# Altium schematic sheet FPGA.SchDoc(FPGA)
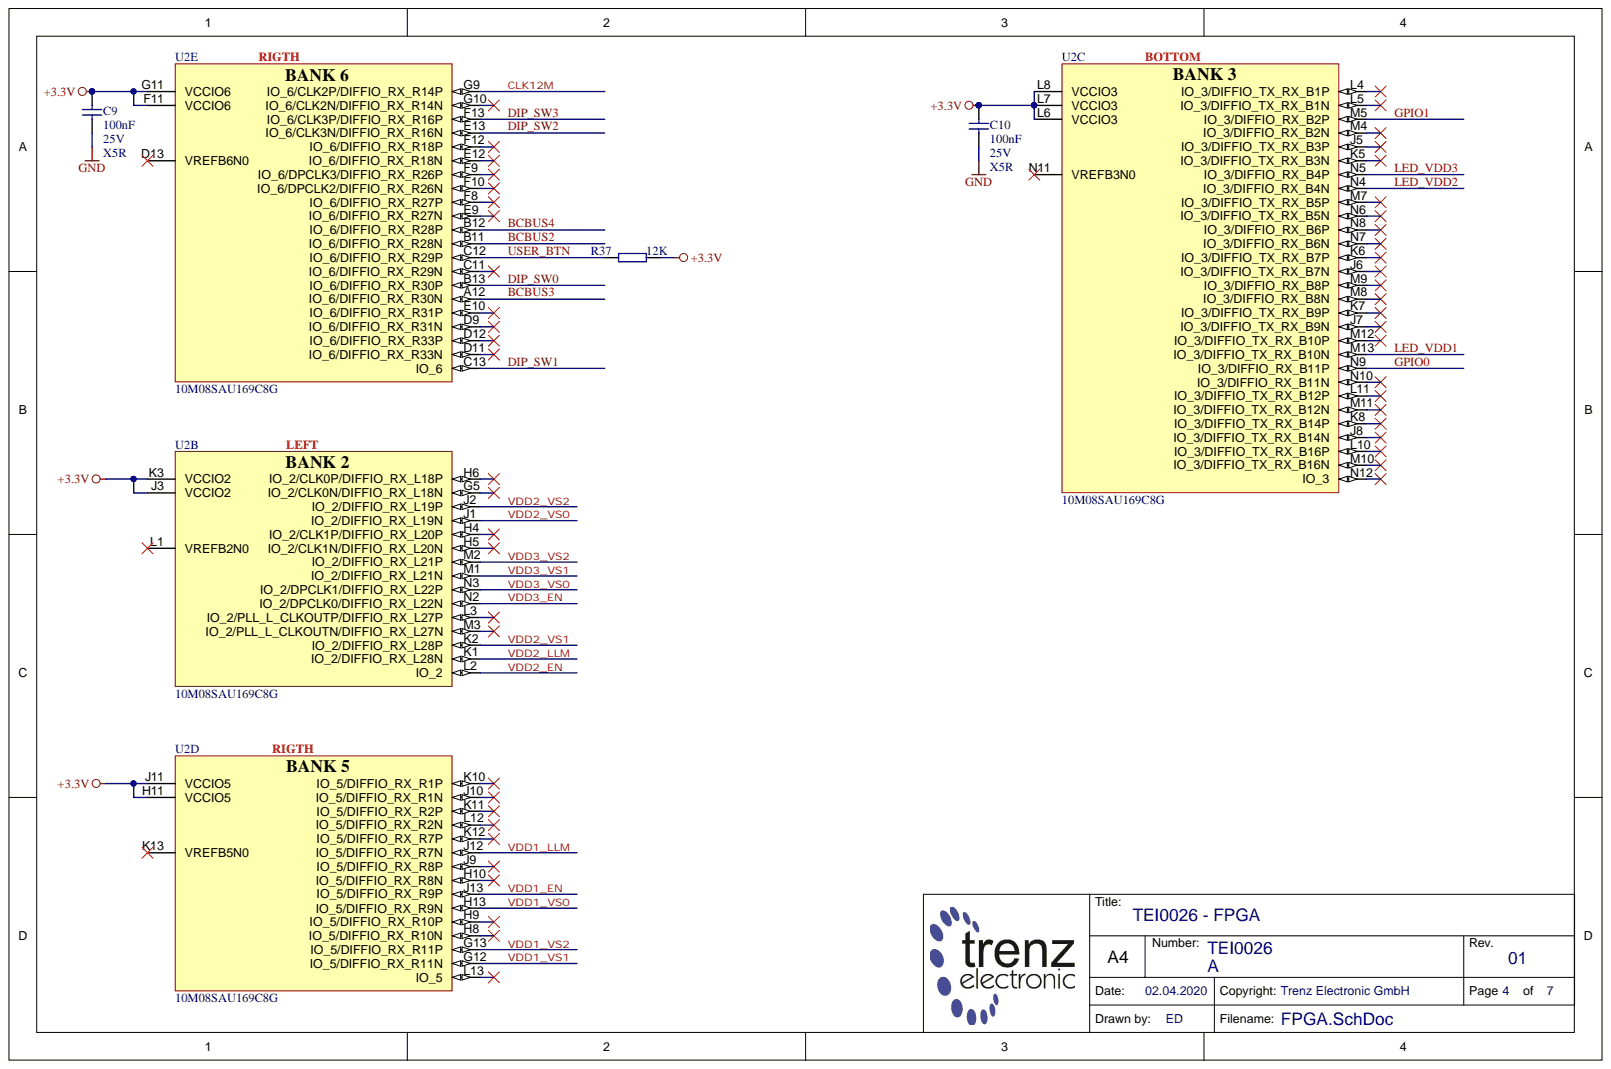
[diagram p0: FPGA.SchDoc]

## Parts
C9  100nF  Voltage: 25V  Technology: X5R  [CAPC1005X55N]
C10  100nF  Voltage: 25V  Technology: X5R  [CAPC1005X55N]
R37  12K  [RESC1005X40N]
U2  10M08SAU169C8G  [BGA169C80P13X13_11X11X15]  (parts B,C,D,E)

## Nets
+3.3V: C9.1 C10.1 R37.2 U2.F11(VCCIO6) U2.G11(VCCIO6) U2.H11(VCCIO5) U2.J3(VCCIO2) U2.J11(VCCIO5) U2.K3(VCCIO2) U2.L6(VCCIO3) U2.L7(VCCIO3) U2.L8(VCCIO3)
GND: C9.2 C10.2
BCBUS2: U2.B11(IO_6/DIFFIO_RX_R28N)
BCBUS3: U2.A12(IO_6/DIFFIO_RX_R30N)
BCBUS4: U2.B12(IO_6/DIFFIO_RX_R28P)
CLK12M: U2.G9(IO_6/CLK2P/DIFFIO_RX_R14P)
DIP_SW0: U2.B13(IO_6/DIFFIO_RX_R30P)
DIP_SW1: U2.C13(IO_6)
DIP_SW2: U2.E13(IO_6/CLK3N/DIFFIO_RX_R16N)
DIP_SW3: U2.F13(IO_6/CLK3P/DIFFIO_RX_R16P)
GPIO0: U2.N9(IO_3/DIFFIO_RX_B11P)
GPIO1: U2.M5(IO_3/DIFFIO_RX_B2P)
LED_VDD1: U2.M13(IO_3/DIFFIO_TX_RX_B10N)
LED_VDD2: U2.N4(IO_3/DIFFIO_RX_B4N)
LED_VDD3: U2.N5(IO_3/DIFFIO_RX_B4P)
USER_BTN: R37.1 U2.C12(IO_6/DIFFIO_RX_R29P)
VDD1_EN: U2.J13(IO_5/DIFFIO_RX_R9P)
VDD1_LLM: U2.J12(IO_5/DIFFIO_RX_R7N)
VDD1_VS0: U2.H13(IO_5/DIFFIO_RX_R9N)
VDD1_VS1: U2.G12(IO_5/DIFFIO_RX_R11N)
VDD1_VS2: U2.G13(IO_5/DIFFIO_RX_R11P)
VDD2_EN: U2.L2(IO_2)
VDD2_LLM: U2.K1(IO_2/DIFFIO_RX_L28N)
VDD2_VS0: U2.J1(IO_2/DIFFIO_RX_L19N)
VDD2_VS1: U2.K2(IO_2/DIFFIO_RX_L28P)
VDD2_VS2: U2.J2(IO_2/DIFFIO_RX_L19P)
VDD3_EN: U2.N2(IO_2/DPCLK0/DIFFIO_RX_L22N)
VDD3_VS0: U2.N3(IO_2/DPCLK1/DIFFIO_RX_L22P)
VDD3_VS1: U2.M1(IO_2/DIFFIO_RX_L21N)
VDD3_VS2: U2.M2(IO_2/DIFFIO_RX_L21P)

Not connected: U2 C11(IO_6/DIFFIO_RX_R29N) D9(IO_6/DIFFIO_RX_R31N) D11(IO_6/DIFFIO_RX_R33N) D12(IO_6/DIFFIO_RX_R33P) D13(VREFB6N0) E9(IO_6/DIFFIO_RX_R27N) E10(IO_6/DIFFIO_RX_R31P) E12(IO_6/DIFFIO_RX_R18N) F8(IO_6/DIFFIO_RX_R27P) F9(IO_6/DPCLK3/DIFFIO_RX_R26P) F10(IO_6/DPCLK2/DIFFIO_RX_R26N) F12(IO_6/DIFFIO_RX_R18P) G5(IO_2/CLK0N/DIFFIO_RX_L18N) G10(IO_6/CLK2N/DIFFIO_RX_R14N) H4(IO_2/CLK1P/DIFFIO_RX_L20P) H5(IO_2/CLK1N/DIFFIO_RX_L20N) H6(IO_2/CLK0P/DIFFIO_RX_L18P) H8(IO_5/DIFFIO_RX_R10N) H9(IO_5/DIFFIO_RX_R10P) H10(IO_5/DIFFIO_RX_R8N) J5(IO_3/DIFFIO_TX_RX_B3P) J6(IO_3/DIFFIO_TX_RX_B7N) J7(IO_3/DIFFIO_TX_RX_B9N) J8(IO_3/DIFFIO_TX_RX_B14N) J9(IO_5/DIFFIO_RX_R8P) J10(IO_5/DIFFIO_RX_R1N) K5(IO_3/DIFFIO_TX_RX_B3N) K6(IO_3/DIFFIO_TX_RX_B7P) K7(IO_3/DIFFIO_TX_RX_B9P) K8(IO_3/DIFFIO_TX_RX_B14P) K10(IO_5/DIFFIO_RX_R1P) K11(IO_5/DIFFIO_RX_R2P) K12(IO_5/DIFFIO_RX_R7P) K13(VREFB5N0) L1(VREFB2N0) L3(IO_2/PLL_L_CLKOUTP/DIFFIO_RX_L27P) L4(IO_3/DIFFIO_TX_RX_B1P) L5(IO_3/DIFFIO_TX_RX_B1N) L10(IO_3/DIFFIO_TX_RX_B16P) L11(IO_3/DIFFIO_TX_RX_B12P) L12(IO_5/DIFFIO_RX_R2N) L13(IO_5) M3(IO_2/PLL_L_CLKOUTN/DIFFIO_RX_L27N) M4(IO_3/DIFFIO_RX_B2N) M7(IO_3/DIFFIO_TX_RX_B5P) M8(IO_3/DIFFIO_RX_B8N) M9(IO_3/DIFFIO_RX_B8P) M10(IO_3/DIFFIO_TX_RX_B16N) M11(IO_3/DIFFIO_TX_RX_B12N) M12(IO_3/DIFFIO_TX_RX_B10P) N6(IO_3/DIFFIO_TX_RX_B5N) N7(IO_3/DIFFIO_RX_B6N) N8(IO_3/DIFFIO_RX_B6P) N10(IO_3/DIFFIO_RX_B11N) N11(VREFB3N0) N12(IO_3)

## Library data of the parts
C9 C10 = 22472: KON 100nF 25V X5R ±10% SMD BF 1005 (0402); Value: 100n; Temperature-Range: -55/+85; Preferred: Yes; Mixed: SMT; Database Table Name: C_1005_0402; Library Name: TE.DbLib; Component Kind: Standard; Case: 1005 (0402); AN: 22472
R37 = 22598: WID SMD 12K 1% 0,063W  BF 1005 (0402); Preferred: Yes; Mixed: SMT; Database Table Name: R_1005_0402; Value: 12K; Tolerance: 1%; Technology: TK100; Library Name: TE.DbLib; Component Kind: Standard; Case: 1005 (0402); AN: 22598
U2 = 27930: Temperature-Range: 0/+85 (Tj); Mixed: SMT; Database Table Name: PROG_LOGIC; Storage-Temperature: -65/+150(Abs); Library Name: TE.DbLib; H-ART: 10M08SAU169C8G; Description: 10M08SAU169C8G; Component Kind: Standard; Case: LFBGA169; AN: 27930; HelpURL: b:\AD\adlib\datasheet\ALTERA_MAX10\
